# Altium schematic sheet SimPadNanoAE.SchDoc
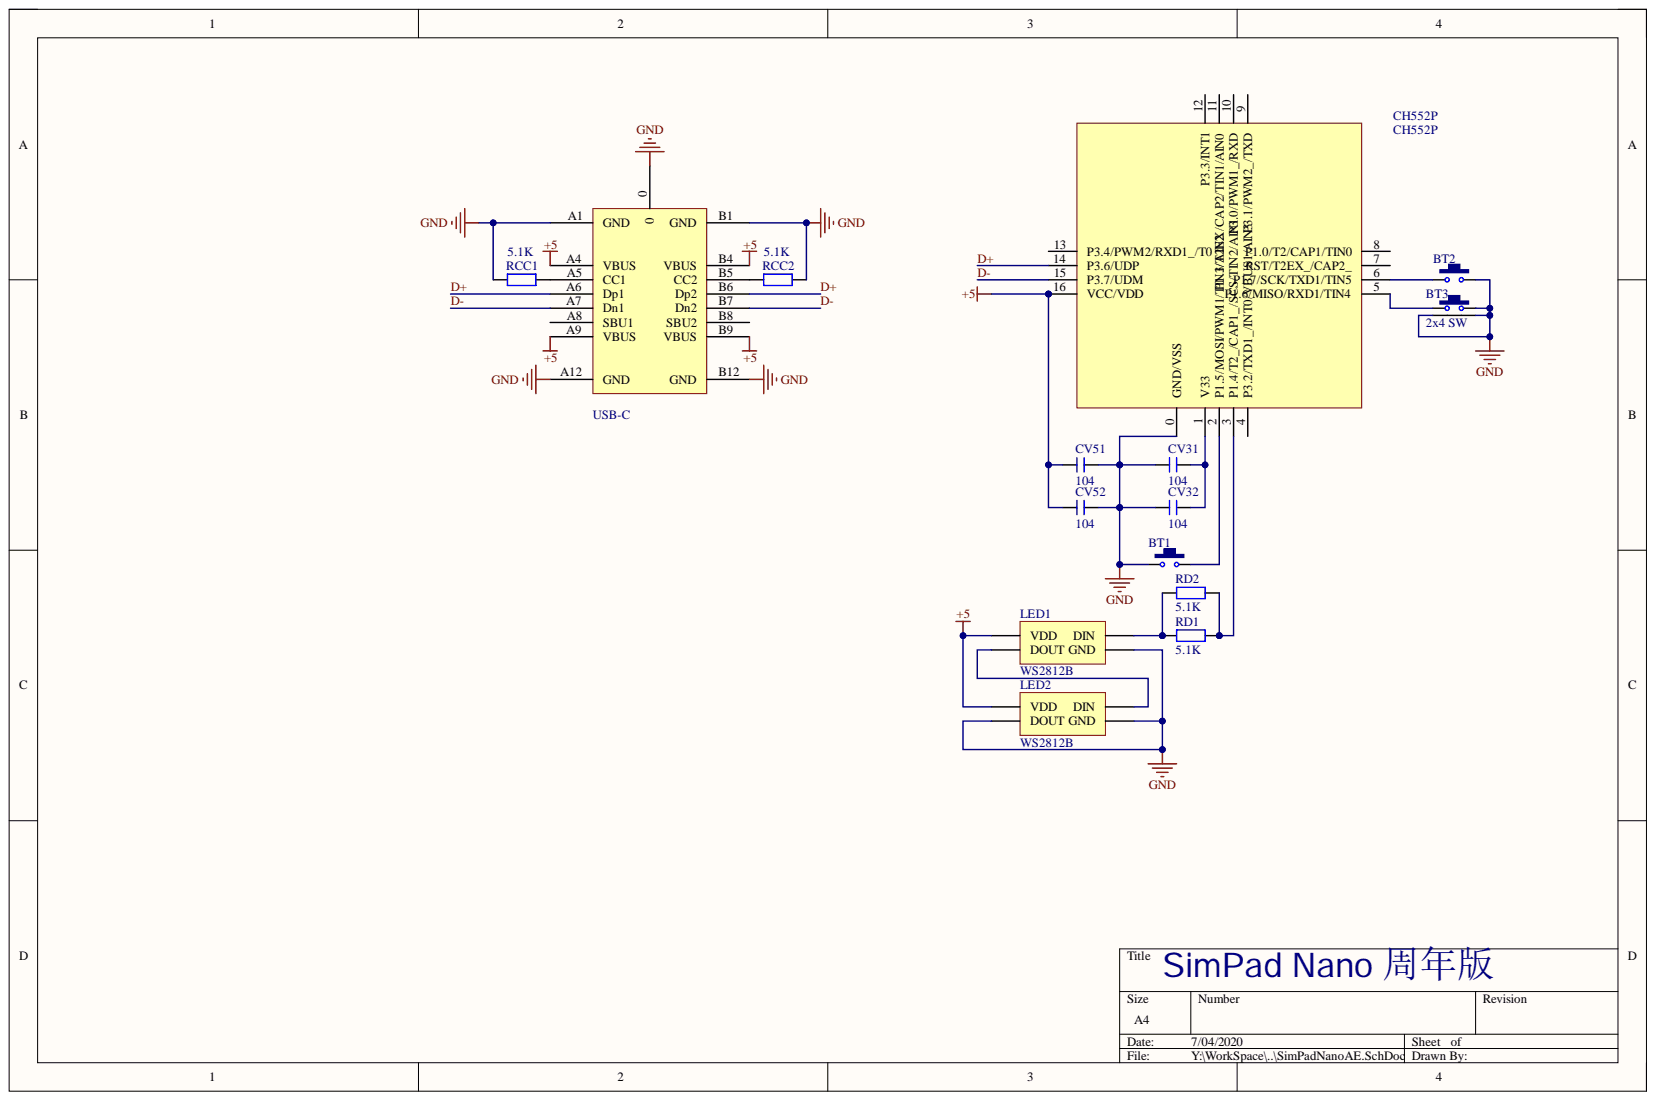
[diagram p0: SimPadNanoAE.SchDoc]

## Parts
BT1  轴体  [Switch_Socket_5P]  (value not drawn)
BT2  轴体  [Switch_Socket_5P]  (value not drawn)
BT3  2x4 SW  [侧按 带柱 2*4*3.5]
CH552P  CH552P  [QFN16]
CV31  104  [0603C]
CV32  104  [0603C]
CV51  104  [0603C]
CV52  104  [0603C]
LED1  WS2812B  [3528B LED]
LED2  WS2812B  [3528B LED]
RCC1  5.1K  [0603R]
RCC2  5.1K  [0603R]
RD1  5.1K  [0603R]
RD2  5.1K  [0603R]
USB  USB-C  [USB-typeC-16P-SMT]

## Nets
+5: CH552P.16 CV51.1 CV52.1 LED1.1 LED2.1 USB.A4 USB.A9 USB.B4 USB.B9
GND: BT1.2 BT2.1 BT3.2 BT3.3 BT3.4 CH552P.0 CV31.2 CV32.2 CV51.2 CV52.2 LED1.3 LED2.2 LED2.3 RCC1.1 RCC2.2 USB.0 USB.A1 USB.A12 USB.B1 USB.B12
D+: CH552P.14 USB.A6 USB.B6
D-: CH552P.15 USB.A7 USB.B7
NetBT1_1: BT1.1 CH552P.2
NetBT2_2: BT2.2 CH552P.6
NetBT3_1: BT3.1 CH552P.5
NetCH552P_1: CH552P.1 CV31.1 CV32.1
NetCH552P_3: CH552P.3 RD1.2 RD2.2
NetLED1_2: LED1.2 LED2.4
NetLED1_4: LED1.4 RD1.1 RD2.1
NetRCC1_2: RCC1.2 USB.A5
NetRCC2_1: RCC2.1 USB.B5

Not connected: CH552P 4 7 8 9 10 11 12 13; USB A8 B8

## Library data of the parts
BT1 BT2 = 轴体: BOM名称: 直插6x6轻触开关; 版权归属: 源创客; Component Kind: Standard; Library Name: SimPad.SchLib; 学习资源: www.ooocke.com
BT3 = SMT 2*4*3.5 侧按: Component Kind: Standard; Library Name: SimPad.SchLib
CH552P = CH552P: WCH CH552P; Component Kind: Standard; Library Name: SimPad.SchLib
CV31 CV32 CV51 CV52 = C: 无极性贴片电容; 版权归属: 源创客; BOM名称: 无极性贴片电容; Component Kind: Standard; Library Name: SimPad.SchLib; 学习资源: www.ooocke.com
LED1 LED2 = WS2812B: WS2812B 全彩LED灯; Component Kind: Standard; Library Name: SimPad.SchLib
RCC1 RCC2 RD1 RD2 = R: 贴片电阻; 版权归属: 源创客; BOM名称: 贴片电阻; Component Kind: Standard; Library Name: SimPad.SchLib; 学习资源: www.ooocke.com
USB = USB-C/16P-SMT: USB-C型母接口; 版权归属: 手柄君; BOM名称: USB-C型母接口; Component Kind: Standard; Library Name: SimPad.SchLib; 学习资源: www.bysb.net
